# Altium schematic sheet LowPassFilter.SchDoc(LowPassFilter)
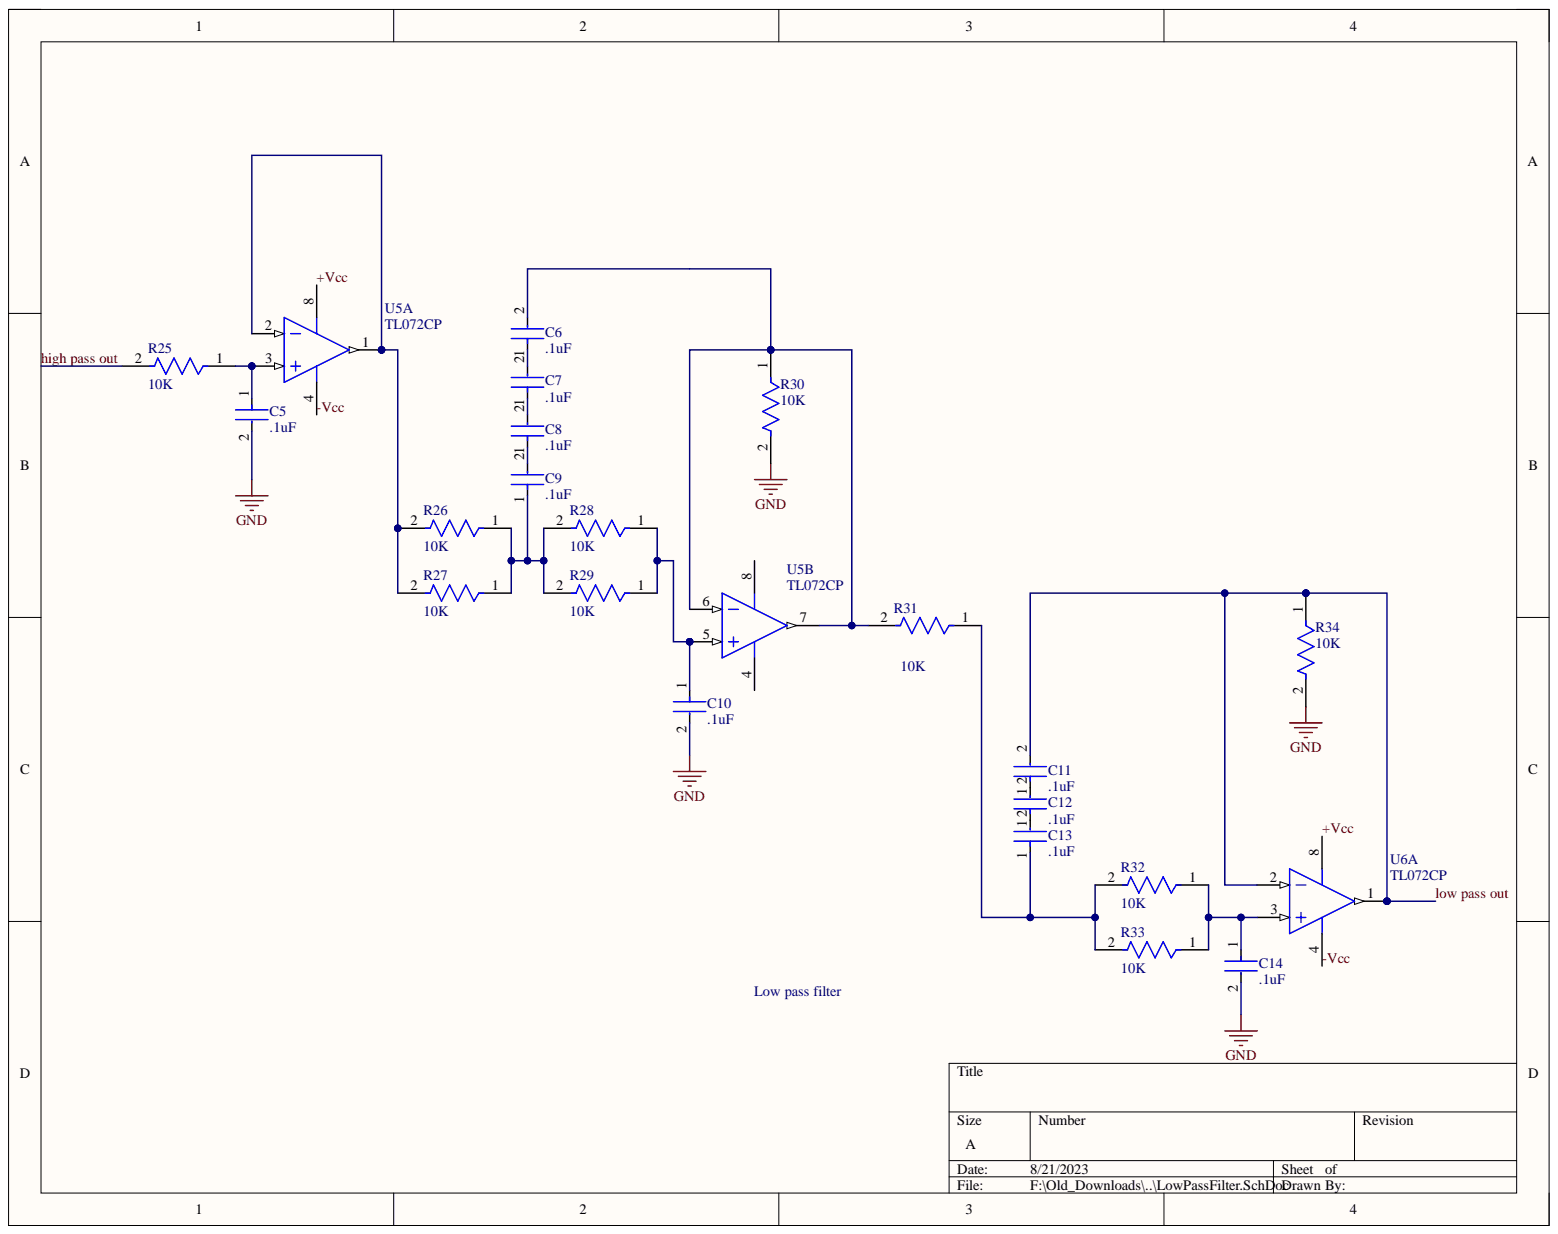
[diagram p0: LowPassFilter.SchDoc]

## Parts
C5  .1uF  [FP-K15H5-MFG]
C6  .1uF  [FP-K15H5-MFG]
C7  .1uF  [FP-K15H5-MFG]
C8  .1uF  [FP-K15H5-MFG]
C9  .1uF  [FP-K15H5-MFG]
C10  .1uF  [FP-K15H5-MFG]
C11  .1uF  [FP-K15H5-MFG]
C12  .1uF  [FP-K15H5-MFG]
C13  .1uF  [FP-K15H5-MFG]
C14  .1uF  [FP-K15H5-MFG]
R25  10K  [FP-CFR-25-MFG]
R26  10K  [FP-CFR-25-MFG]
R27  10K  [FP-CFR-25-MFG]
R28  10K  [FP-CFR-25-MFG]
R29  10K  [FP-CFR-25-MFG]
R30  10K  [FP-CFR-25-MFG]
R31  10K  [FP-CFR-25-MFG]
R32  10K  [FP-CFR-25-MFG]
R33  10K  [FP-CFR-25-MFG]
R34  10K  [FP-CFR-25-MFG]
U5  TL072CP  [P0008A]  (parts A,B)
U6  TL072CP  [P0008A]  (part A)

## Nets
GND: C5.2 C10.2 C14.2 R30.2 R34.2
+Vcc: U5.8 U6.8
-Vcc: U5.4 U6.4
high pass out: R25.2
low pass out: C11.2 R34.1 U6.1 U6.2
NetC5_1: C5.1 R25.1 U5.3
NetC6_1: C6.1 C7.2
NetC6_2: C6.2 R30.1 R31.2 U5.6 U5.7
NetC7_1: C7.1 C8.2
NetC8_1: C8.1 C9.2
NetC9_1: C9.1 R26.1 R27.1 R28.2 R29.2
NetC10_1: C10.1 R28.1 R29.1 U5.5
NetC11_1: C11.1 C12.2
NetC12_1: C12.1 C13.2
NetC13_1: C13.1 R31.1 R32.2 R33.2
NetC14_1: C14.1 R32.1 R33.1 U6.3
NetR26_2: R26.2 R27.2 U5.1 U5.2

## Library data of the parts
C5-C14 = CMP-02417-000048-1: CAP CER 0.1UF 50V X7R RADIAL; Capacitance: 100nF; Lead Diameter: 0.5mm; Lead Length: 30mm; Lead/Base Style: Formed Leads; Tolerance: 10%; Case/Package: Dipped; Component Kind: Standard; Construction: RADIAL; Depth: 2.5mm; Diameter: 500um; Dielectric: X7R; Height: 4mm; Height - Seated (Max): 0.1inch; Lead Pitch: 5mm; Length: 4mm; Library Name: Altium Content Vault; Max Operating Temperature: 125°C; Min Operating Temperature: -55°C; Mount: Through Hole; Package Quantity: 5000; Packaging: Bulk; Radiation Hardening: No; RoHS Compliant: Yes; Series: K; Termination: Radial; Thickness: 2.6mm; Voltage: 50V; Voltage Rating: 50V; Voltage Rating (DC): 50V; Weight: 0.005644oz; Width: 2.5mm
R25-R34 = CMP-03413-000009-1: RES 10 OHM 1/4W 5% AXIAL; Max Power Dissipation: 250mW; Resistance: 10R; Temperature Coefficient: 500ppm/°C; Case/Package: Axial; Component Kind: Standard; Length: 0.248inch; Library Name: Altium Content Vault; Max Operating Temperature: 155°C; Min Operating Temperature: -55°C; Number of Terminations: 2; Package Quantity: 200; Packaging: Bulk; Resistor Type: Carbon Film; RoHS Compliant: Yes; Series: Carbon Film; Tolerance: 5%
U5 U6 = CMP-0017-00950-2: Dual Low-Noise JFET-Input General-Purpose Operational Amplifier, 7 to 36 V, 0 to 70 degC, 8-pin DIP (P8), Pb-Free (RoHS); Manufacturer: Texas Instruments; CMRR(Min)(dB): 70; GBW(Typ)(MHz): 3; IIB(Max)(pA): 200; Iq per channel(Max)(mA): 2.5; Number of Channels: 2; Offset Drift(Typ)(uV/C): 18; Rating: Catalog; Slew Rate(Typ)(V/us): 13; Sub Family: General Purpose Amplifier, High Supply Voltage Amplifier (>=30V); Total Supply Voltage(Max)(+5V is 5, +/-5V is 10): 36; Total Supply Voltage(Min)(+5V is 5, +/-5V is 10): 7; Vio (25C)(Max)(mV): 10; Code_JEDEC: MS-001; Component Kind: Standard; ComponentLink1Description: Manufacturer URL; ComponentLink1URL: http://www.ti.com/; ComponentLink2Description: Datasheet; ComponentLink2URL: http://www.ti.com/lit/ds/symlink/tl072.pdf; ComponentLink3Description: Package Specification; ComponentLink3URL: http://www.ti.com/litv/pdf/mpdi001b; DatasheetVersion: SLOS080J; Eco-Plan: Pb-Free (RoHS); Library Name: Altium Content Vault; Mounting Technology: Through Hole; PackageDescription: 8-Pin Plastic In-Line, Body 9.59 x 6.35 mm, Pitch 2.54 mm; PackageReference: P0008A; PackageVersion: 4040082/E, 04/2010; PartNumber: TL072CP; Vn at 1kHz(Typ)(nV/rtHz): 18
